# Altium schematic sheet CW551_MainBoard_Power.SchDoc
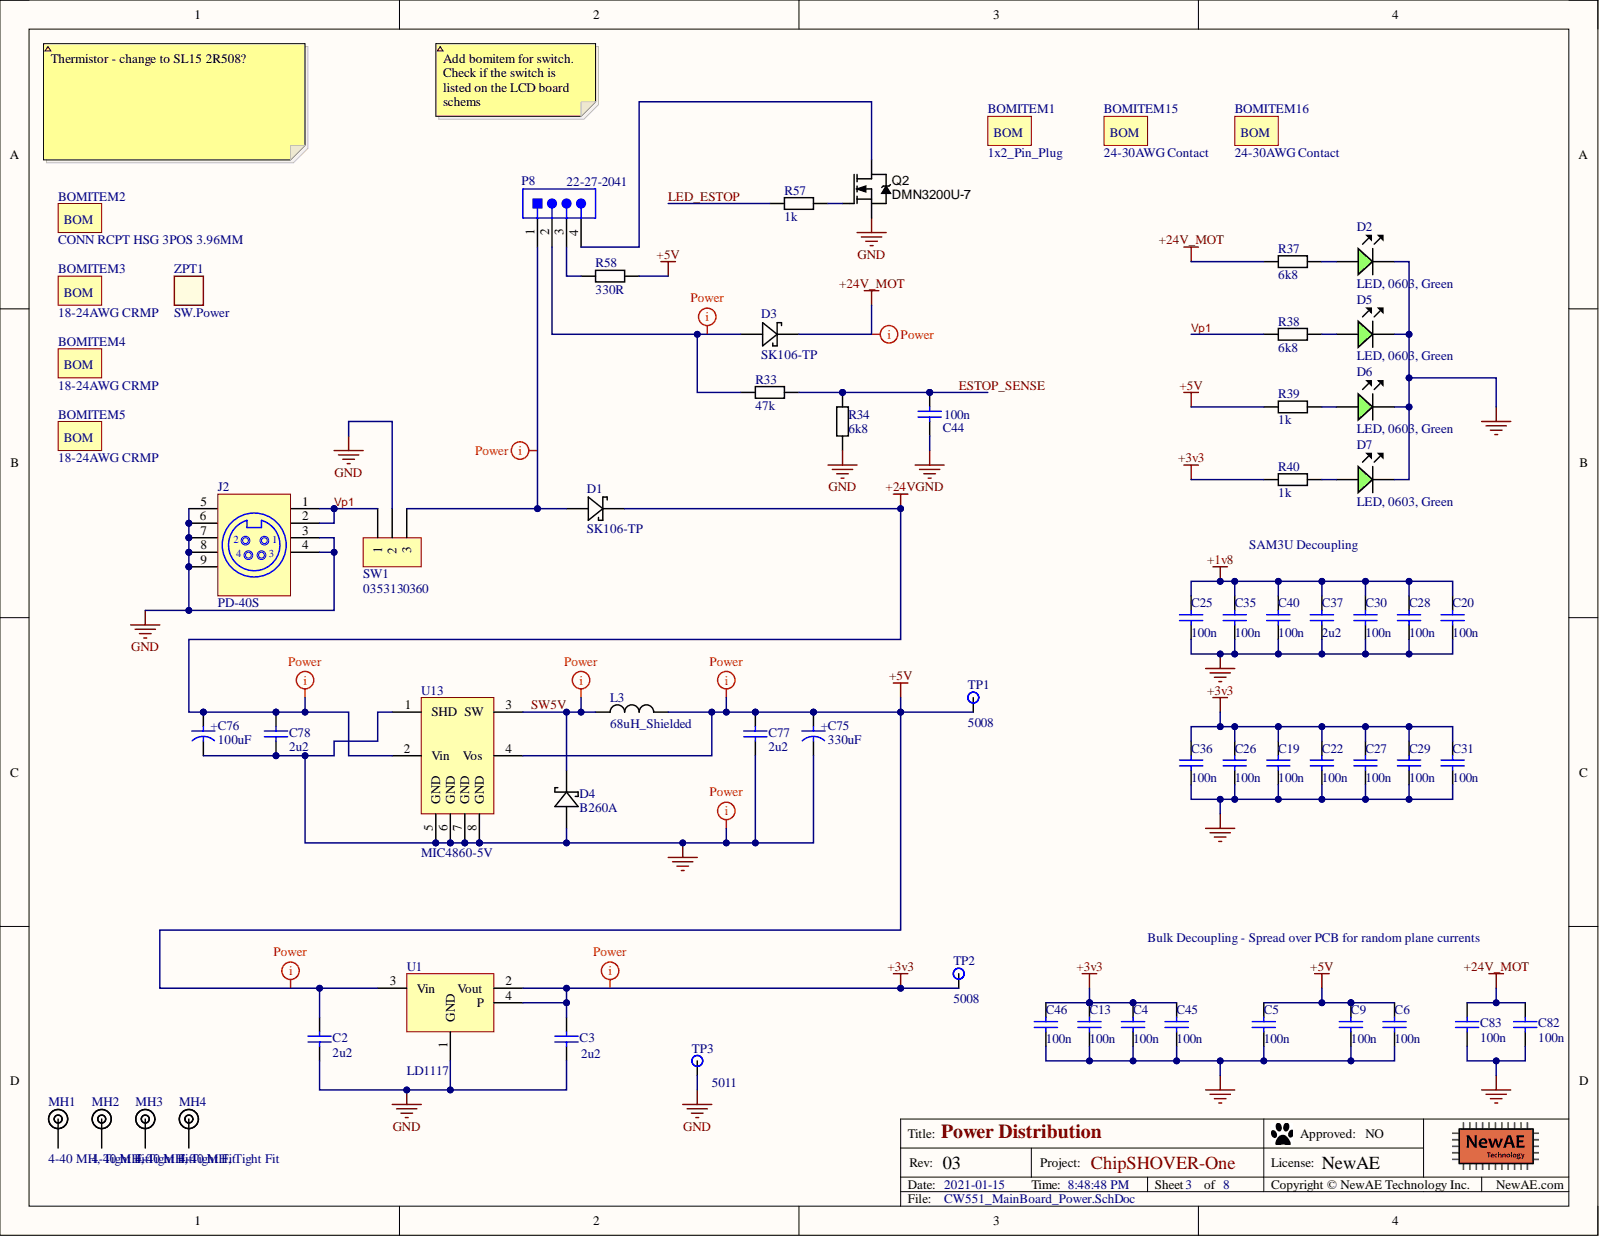
[diagram p0: CW551_MainBoard_Power.SchDoc]

## Parts
BOMITEM1  1x2_Pin_Plug
BOMITEM2  CONN RCPT HSG 3POS 3.96MM
BOMITEM3  18-24AWG CRMP
BOMITEM4  18-24AWG CRMP
BOMITEM5  18-24AWG CRMP
BOMITEM15  24-30AWG Contact
BOMITEM16  24-30AWG Contact
C2  2u2  [0603]
C3  2u2  [0603]
C4  100n  [CAPC0603_M]
C5  100n  [CAPC0603_M]
C6  100n  [CAPC0603_M]
C9  100n  [CAPC0603_M]
C13  100n  [CAPC0603_M]
C19  100n  [CAPC0603_M]
C20  100n  [CAPC0603_M]
C22  100n  [CAPC0603_M]
C25  100n  [CAPC0603_M]
C26  100n  [CAPC0603_M]
C27  100n  [CAPC0603_M]
C28  100n  [CAPC0603_M]
C29  100n  [CAPC0603_M]
C30  100n  [CAPC0603_M]
C31  100n  [CAPC0603_M]
C35  100n  [CAPC0603_M]
C36  100n  [CAPC0603_M]
C37  2u2  [CAPC0603_M]
C40  100n  [CAPC0603_M]
C44  100n  [0603]
C45  100n  [CAPC0603_M]
C46  100n  [CAPC0603_M]
C75  330uF  [CAP_AL_D6.3]
C76  100uF  [CAP_AL_D6.3]
C77  2u2  [0603]
C78  2u2  [0603]
C82  100n  [CAPC0603_M]
C83  100n  [CAPC0603_M]
D1  SK106-TP  [SMCJ]
D2  LED, 0603, Green  [LED-0603-GREEN]
D3  SK106-TP  [SMCJ]
D4  B260A  [DO-214AC]
D5  LED, 0603, Green  [LED-0603-GREEN]
D6  LED, 0603, Green  [LED-0603-GREEN]
D7  LED, 0603, Green  [LED-0603-GREEN]
J2  PD-40S  [CUI-PD-40S_V]
L3  68uH_Shielded  [NonStandard]
MH1  4-40 MH, Tight Fit  [MOUNT_#4_TIGHT]  (mechanical)
MH2  4-40 MH, Tight Fit  [MOUNT_#4_TIGHT]  (mechanical)
MH3  4-40 MH, Tight Fit  [MOUNT_#4_TIGHT]  (mechanical)
MH4  4-40 MH, Tight Fit  [MOUNT_#4_TIGHT]  (mechanical)
P8  22-27-2041  [MOLX-22-27-2041_V]
Q2  DMN3200U-7  [SOT23]
R33  47k  [RESC1608X55N]
R34  6k8  [0603]
R37  6k8  [0603]
R38  6k8  [0603]
R39  1k  [0603]
R40  1k  [0603]
R57  1k  [0603]
R58  330R  [0603]
SW1  0353130360  [0353130360]
TP1  5008  [KEYSTONE5008]
TP2  5008  [KEYSTONE5008]
TP3  5011  [KEYSTONE5011]
U1  LD1117  [SOT-223]
U13  MIC4860-5V  [SOIC-8]
ZPT1  SW.Power  (mechanical)

## Nets
+1v8: C20.1 C25.1 C28.1 C30.1 C35.1 C37.1 C40.1
+3v3: C3.1 C4.1 C13.1 C19.1 C22.1 C26.1 C27.1 C29.1 C31.1 C36.1 C45.1 C46.1 R40.1 TP2.1 U1.2(Vout) U1.4(P)
+5V: C2.1 C5.1 C6.1 C9.1 C75.1 C77.1 L3.2 R39.1 R58.2 TP1.1 U1.3(Vin) U13.4(Vos)
+24V: C76.1 C78.1 D1.1 U13.2(Vin)
+24V_MOT: C82.2 C83.2 D3.1 R37.1
GND: C2.2 C3.2 C4.2 C5.2 C6.2 C9.2 C13.2 C19.2 C20.2 C22.2 C25.2 C26.2 C27.2 C28.2 C29.2 C30.2 C31.2 C35.2 C36.2 C37.2 C40.2 C44.2 C45.2 C46.2 C75.2 C76.2 C77.2 C78.2 C82.1 C83.1 D2.K D4.A D5.K D6.K D7.K J2.3 J2.4 J2.5 J2.6 J2.7 J2.8 J2.9 Q2.2 R34.2 SW1.2 TP3.1 U1.1(GND) U13.1(SHD) U13.5(GND) U13.6(GND) U13.7(GND) U13.8(GND)
ESTOP_SENSE: C44.1 R33.1 R34.1
LED_ESTOP: R57.1
SW5V: D4.K L3.1 U13.3(SW)
Vp1: J2.1 J2.2 R38.1 SW1.1
NetD1_2: D1.2 P8.1 SW1.3
NetD2_A: D2.A R37.2
NetD3_2: D3.2 P8.2 R33.2
NetD5_A: D5.A R38.2
NetD6_A: D6.A R39.2
NetD7_A: D7.A R40.2
NetP8_3: P8.3 R58.1
NetP8_4: P8.4 Q2.3
NetQ2_1: Q2.1 R57.2

Not connected: MH1 1; MH2 1; MH3 1; MH4 1

## Library data of the parts
BOMITEM1 = Molex_2_Pin_Plug: CONN HOUSING 2POS .100 HI PRESS; Manufacture 1: Molex; Component Group: Connector; Database Table Name: main; PP Material Stack: 0; Component Kind: Standard; Component Type: Standard; Library Name: NewAE_AltiumLib.DBLib; Manufacture Part Number 1: 10-11-2023; Part Number: Molex_2_Pin_Plug; PP Rotation: 0; RoHS: Yes; Supplier 1: Digi-Key; Supplier Part Number 1: WM2601-ND
BOMITEM2 = 0351560300: Manufacture 1: Molex; Component Group: Mechanical; Database Table Name: main; PP Material Stack: 0; Component Kind: Standard; Component Type: Standard; Description: CONN RCPT HSG 3POS 3.96MM; Library Name: NewAE_AltiumLib.DBLib; Manufacture Part Number 1: 0351560300; Part Number: 0351560300; Pin Count: 3; PP Rotation: 0; RoHS: Yes; Supplier 1: Digi-Key; Supplier Part Number 1: WM3143-ND
BOMITEM3-BOMITEM5 = 0502179101: CONN SOCKET 18-24AWG CRIMP TIN for 0351560300; Manufacture 1: Molex; Component Group: Mechanical; Database Table Name: main; PP Material Stack: 0; Component Kind: Standard; Component Type: Standard; Library Name: NewAE_AltiumLib.DBLib; Manufacture Part Number 1: 0502179101; Part Number: 0502179101; Pin Count: 1; PP Rotation: 0; RoHS: Yes; Supplier 1: Digi-Key; Supplier Part Number 1: WM3318-ND
BOMITEM15 BOMITEM16 = 0050291900: CONN FEMALE 24-30AWG TIN; Manufacture 1: Molex; Component Group: Mechanical; Database Table Name: main; PP Material Stack: 0; Component Kind: Standard; Component Type: Standard; Library Name: NewAE_AltiumLib.DBLib; Manufacture Part Number 1: 0050291900; Part Number: 0050291900; Pin Count: 1; PP Rotation: 0; RoHS: Yes; Supplier 1: Digi-Key; Supplier Part Number 1: WM5269CT-ND
C2 C3 C77 C78 = CCHIP-2u2-0603: 2u2, 0603; Manufacture 1: Samsung; Manufacture Part Number 1: CL10B225KP8NNNC; Case-Metric: 1608; Component Group: Capacitor; Database Table Name: main; Rated Voltage: 10VDC; Case-EIA: 0603; Component Kind: Standard; Component Type: Standard; LCSC Equivalent: C57895; Library Name: NewAE_AltiumLib.DBLib; Mounting Technology: SMT; Part Number: CCHIP-2u2-0603; Pin Count: 2; Supplier 1: Digi-Key; Supplier Part Number 1: 1276-1134-2-ND; Value: 2u2
C4-C6 C9 C13 C19 C20 C22 C25-C31 C35 C36 C40 C45 C46 C82 C83 = CCHIP-100n-0603: 100n, 0603; Manufacture 1: Kemet; Manufacture Part Number 1: C0603C104K5RACTU; Case-EIA: 0603; Case-Metric: 1608; Component Group: Capacitor; Rated Voltage: 50V; 0_TEMP: CAPC0603_M; Component Kind: Standard; Component Type: Standard; LCSC Equivalent: C1591; Library Name: CW550_MAIN.SCHLIB; Mounting Technology: SMT; Part Number: CCHIP-100n-0603; Pin Count: 2; Supplier 1: Digi-Key; Supplier Part Number 1: 399-5089-2-ND; Value: 100n
C37 = CCHIP-2u2-0603: 2u2, 0603; Manufacture 1: Samsung; Manufacture Part Number 1: CL10B225KP8NNNC; Case-EIA: 0603; Case-Metric: 1608; Component Group: Capacitor; Rated Voltage: 10VDC; 0_TEMP: CAPC0603_M; Component Kind: Standard; Component Type: Standard; LCSC Equivalent: C57895; Library Name: CW550_MAIN.SCHLIB; Mounting Technology: SMT; Part Number: CCHIP-2u2-0603; Pin Count: 2; Supplier 1: Digi-Key; Supplier Part Number 1: 1276-1134-2-ND; Value: 2u2
C44 = CCHIP-100n-0603: 100n, 0603; Manufacture 1: Kemet; Manufacture Part Number 1: C0603C104K5RACTU; Case-Metric: 1608; Component Group: Capacitor; Database Table Name: main; Rated Voltage: 50VDC; Case-EIA: 0603; Component Kind: Standard; Component Type: Standard; LCSC Equivalent: C1591; Library Name: NewAE_AltiumLib.DBLib; Mounting Technology: SMT; Part Number: CCHIP-100n-0603; Pin Count: 2; Supplier 1: Digi-Key; Supplier Part Number 1: 399-5089-2-ND; Value: 100n
C75 = Cap_10v_330uF: CAP ALUM 330UF 20% 10V RADIAL; Manufacture 1: Panasonic Electronic Components; Manufacture Part Number 1: ECA-1AM331; Component Group: Capacitor; Database Table Name: main; PP Material Stack: 0; Component Kind: Standard; Component Type: Standard; Library Name: NewAE_AltiumLib.DBLib; Mounting Technology: Through-hole; Part Number: Cap_10v_330uF; Pin Count: 2; PP Rotation: 0; RoHS: Yes; Supplier 1: Digi-Key; Supplier Part Number 1: P5125-ND; Value: 330uF
C76 = Cap_35v_100uF: CAP ALUM 100UF 20% 35V RADIAL; Manufacture 1: Panasonic Electronic Components; Manufacture Part Number 1: ECA-1VM101; Component Group: Capacitor; Database Table Name: main; PP Material Stack: 0; Component Kind: Standard; Component Type: Standard; Library Name: NewAE_AltiumLib.DBLib; Mounting Technology: Through-hole; Part Number: Cap_35v_100uF; Pin Count: 2; PP Rotation: 0; RoHS: Yes; Supplier 1: Digi-Key; Supplier Part Number 1: P5165-ND; Value: 100uF
D1 D3 = SK106-TP: Diode, Schottky, 60V, 10A; Manufacture 1: Micro Commercial Co; 0_TEMP: DO-214AB; Case-EIA: SMC; Rated Voltage: 60VDC; Component Group: Diode; Component Kind: Standard; Component Type: Standard; Library Name: CW550_MAIN.SCHLIB; Manufacture Part Number 1: SK106-TP; Mounting Technology: SMT; Part Number: SK106-TP; Pin Count: 2; Supplier 1: Digi-Key; Supplier Part Number 1: SK106-TPCT-ND; Temperature Range: -55C to 125C
D2 D5-D7 = LEDCHIP_0603_GREEN: Chip LED, Green; Manufacture 1: Kingbright; Manufacture Part Number 1: APT1608CGCK; Component Group: Optical; Database Table Name: main; PP Material Stack: 16; PP Rotation: -90; Component Kind: Standard; Component Type: Standard; LCSC Equivalent: C192666; Library Name: NewAE_AltiumLib.DBLib; Mounting Technology: SMT; Part Number: LEDCHIP_0603_GREEN; Pin Count: 2; Supplier 1: Digi-Key; Supplier Part Number 1: 754-1116-1-ND
D4 = DIODE_SCHOTTKY_2A: DIODE SCHOTTKY 60V 2A SMA; Manufacture 1: Diodes Incorporated; Manufacture Part Number 1: B260A-13-F; Database Table Name: main; PP Material Stack: 0; Component Group: Diode; Component Kind: Standard; Component Type: Standard; LCSC Equivalent: C109094; Library Name: NewAE_AltiumLib.DBLib; Mounting Technology: SMT; Part Number: DIODE_SCHOTTKY_2A; Pin Count: 2; PP Rotation: 0; RoHS: Yes; Supplier 1: Digi-Key; Supplier Part Number 1: B260A-FDICT-ND
J2 = CUI-PD-40S-4: Right Angle Power DIN Jack with Shielding, 4 Position, 7.5 A, 20 V, Through Hole, RoHS, Tray; 0_TEMP: CUI-PD-40S_V; Component Kind: Standard; ComponentLink1Description: Manufacturer URL; ComponentLink1URL: http://www.cui.com/; ComponentLink2Description: Datasheet; ComponentLink2URL: http://www.cui.com/product/resource/pd-40s.pdf; DatasheetVersion: Rev. B; Mount: Through Hole; Mounting Technology: Through Hole; Package Quantity: 2720; PackageDescription: Power Jack, 4 Position, Body 17.4 x 16 mm, Height 15 mm, Tail Length 3.5 mm; Packaging: Tray; PartNumber: PD-40S; Pins: 4; RoHSCompliant: True
L3 = IND_68u_1.5A: FIXED IND 68UH 1.5A 120 MOHM SMD; Manufacture 1: Sumida America Components Inc.; Manufacture Part Number 1: CDRH125NP-680MC; Component Group: Inductor; Database Table Name: main; PP Material Stack: 0; Component Kind: Standard; Component Type: Standard; Library Name: NewAE_AltiumLib.DBLib; Mounting Technology: SMT; Part Number: IND_68u_1.5A; Pin Count: 2; PP Rotation: 0; RoHS: Yes; Supplier 1: Digi-Key; Supplier Part Number 1: 308-1454-1-ND
MH1-MH4 = MOUNT_#4_TIGHT: Machine screw 4-40 Mounting Hole; Component Group: Mechanical; Database Table Name: main; PP Material Stack: 0; Component Type: Mechanical; Library Name: NewAE_AltiumLib.DBLib; Part Number: MOUNT_#4_TIGHT; Pin Count: 1; PP Rotation: 0
P8 = CMP-2000-05243-1: Male Header, Pitch 2.54 mm, 1 x 4 Position, Height 11.7 mm, Tail Length 3.56 mm, RoHS, Bulk; Manufacturer: Molex; Current rating: 4A; Voltage rating: 250V; Component Kind: Standard; Contact material: Tinplatedbrass; Datasheet URL: http://www.molex.com/pdm_docs/sd/022272041_sd.pdf; Datasheet Version: Rev. F, 06/2009; Housing material: Nylon(UL94V-0); Library Name: Altium Content Vault; Manufacturer URL: http://www.molex.com/; Operating temperature: 0degCto+75degC
Q2 = DMN3200U-7: MOSFET N-CH 30V 2.2A SOT23-3; Manufacture 1: Diodes Inc.; Database Table Name: main; PP Material Stack: 0; Rated Power: 3A; Component Group: MOSFET; Component Kind: Standard; Component Type: Standard; LCSC Equivalent: C461018; Library Name: NewAE_AltiumLib.DBLib; Manufacture Part Number 1: DMN3200U-7; Mounting Technology: SMT; Part Number: DMN3200U-7; Pin Count: 3; PP Rotation: 0; Rated Voltage: 30V; RoHS: Yes; Supplier 1: Digi-Key; Supplier Part Number 1: DMN3200U-7DICT-ND
R33 = RCHIP-47K-0603: 47k res, 0603, 1%, 1/10W; Manufacture 1: Yageo; Manufacture Part Number 1: RC0603FR-0747KL; Case-EIA: 0603; Case-Metric: 1608; Component Group: Resistor; Rated Power: 0.1W; Rated Voltage: 75VDC; 0_TEMP: RESC1608X55N; Component Kind: Standard; Component Type: Standard; Library Name: CW550_MAIN.SCHLIB; Mounting Technology: SMT; Part Number: RCHIP-47K-0603; Pin Count: 2; Supplier 1: Digi-Key; Supplier Part Number 1: 311-47.0KHRCT-ND; Temperature Range: -55C to 155C; Tolerance: 1%; Value: 47k
R34 R37 R38 = RCHIP-6K8-0603: 6k8 res, 0603, 1%, 1/10W; Manufacture 1: Yageo; Manufacture Part Number 1: RC0603FR-076K8L; Case-Metric: 1608; Component Group: Resistor; Database Table Name: main; Rated Power: 0.1W; Rated Voltage: 75VDC; Case-EIA: 0603; Component Kind: Standard; Component Type: Standard; Library Name: NewAE_AltiumLib.DBLib; Mounting Technology: SMT; Part Number: RCHIP-6K8-0603; Pin Count: 2; Supplier 1: Digi-Key; Supplier Part Number 1: 311-6.80KHRCT-ND; Temperature Range: -55C to 155C; Tolerance: 1%; Value: 6k8
R39 R40 R57 = RCHIP-1K-0603: 1k res, 0603, 1%, 1/10W; Manufacture 1: Yageo; Manufacture Part Number 1: RC0603FR-071KL; Case-Metric: 1608; Component Group: Resistor; Database Table Name: main; Rated Power: 0.1W; Rated Voltage: 75VDC; Case-EIA: 0603; Component Kind: Standard; Component Type: Standard; Library Name: NewAE_AltiumLib.DBLib; Mounting Technology: SMT; Part Number: RCHIP-1K-0603; Pin Count: 2; Supplier 1: Digi-Key; Supplier Part Number 1: 311-1.00KHRCT-ND; Temperature Range: -55C to 155C; Tolerance: 1%; Value: 1k
R58 = RCHIP-330R-0603: 330R res, 0603, 1%, 1/10W; Manufacture 1: Yageo; Manufacture Part Number 1: RC0603FR-07330RL; Case-Metric: 1608; Component Group: Resistor; Database Table Name: main; Rated Power: 0.1W; Rated Voltage: 75VDC; Case-EIA: 0603; Component Kind: Standard; Component Type: Standard; Library Name: NewAE_AltiumLib.DBLib; Mounting Technology: SMT; Part Number: RCHIP-330R-0603; Pin Count: 2; Supplier 1: Digi-Key; Supplier Part Number 1: 311-330HRCT-ND; Temperature Range: -55C to 155C; Tolerance: 1%; Value: 330R
SW1 = HDR_3PIN_.156_0353130360: 0.156" Header, Low Profile, Shrouded; Manufacture 1: Molex; Component Group: Connector; Database Table Name: main; PP Material Stack: 0; Component Kind: Standard; Component Type: Standard; Library Name: NewAE_AltiumLib.DBLib; Manufacture Part Number 1: 0353130360; Mounting Technology: Through-hole; Part Number: HDR_3PIN_.156_0353130360; Pin Count: 3; PP Rotation: 0; RoHS: Yes; Supplier 1: Digi-Key; Supplier Part Number 1: WM13190-ND
TP1 TP2 = KEYSTONE_5008: TEST POINT PC COMPACT .063"D ORG; Database Table Name: main; Component Group: Test Point; Component Kind: Standard; Component Type: Standard; Library Name: NewAE_AltiumLib.DBLib; Mounting Technology: Through-hole; Part Number: KEYSTONE_5008; Pin Count: 1; Supplier 1: Digi-Key; Supplier Part Number 1: 36-5008-ND
TP3 = KEYSTONE_5011: TEST POINT PC MULTI PURPOSE BLK; Database Table Name: main; Component Group: Test Point; Component Kind: Standard; Component Type: Standard; Library Name: NewAE_AltiumLib.DBLib; Mounting Technology: Through-hole; Part Number: KEYSTONE_5011; Pin Count: 1; Supplier 1: Digi-Key; Supplier Part Number 1: 36-5011-ND
U1 = LD1117S33: IC REG LIN POS 3.3V 800MA SOT223; Manufacture 1: STMicroelectronics; Manufacture Part Number 1: LD1117S33TR; Component Group: Analog IC; Database Table Name: main; PP Material Stack: 0; Rated Voltage: 15V; Component Kind: Standard; Component Type: Standard; LCSC Equivalent: C86781; Library Name: NewAE_AltiumLib.DBLib; Mounting Technology: SMT; Part Number: LD1117S33; Pin Count: 3; PP Rotation: 0; RoHS: Yes; Supplier 1: Digi-Key; Supplier Part Number 1: 497-1242-1-ND
U13 = Reg_Switching_5v_1A: IC REG BUCK BOOST 5V 1.3A 8SOIC; Manufacture 1: Microchip Technology; Manufacture Part Number 1: MIC4680-5.0YM-TR; Component Group: Analog IC; Database Table Name: main; PP Material Stack: 0; Component Kind: Standard; Component Type: Standard; Library Name: NewAE_AltiumLib.DBLib; Mounting Technology: SMT; Part Number: Reg_Switching_5v_1A; Pin Count: 8; PP Rotation: 0; RoHS: Yes; Supplier 1: Digi-Key; Supplier Part Number 1: 576-1221-1-ND
ZPT1 = PART_GENERIC: Switch, power; MFR: E-Switch; MFR_PN: R1973ABLKGILFF4; ATTR1: ***; MIN_BUY: 1; PKG_MARKING: **; RATING: 120VAC, 9A; VENDOR: D-K; VENDOR_PN: R1973ABLKGILFF4-ND; ATTR2: ***; ATTR3: ***; FUNCTIONALITY: Power; Library Name: DEVICE.SchLib; LOC: ***; PACKAGE: N/A; PCB_AREA: ***; PKG_ID: ***; PRICE: 0; TOLERANCE: ***; TYPE: Illum.; Usage: 1; VALUE: SW.Power
